# Altium schematic sheet Stage3G_current_limit.SchDoc
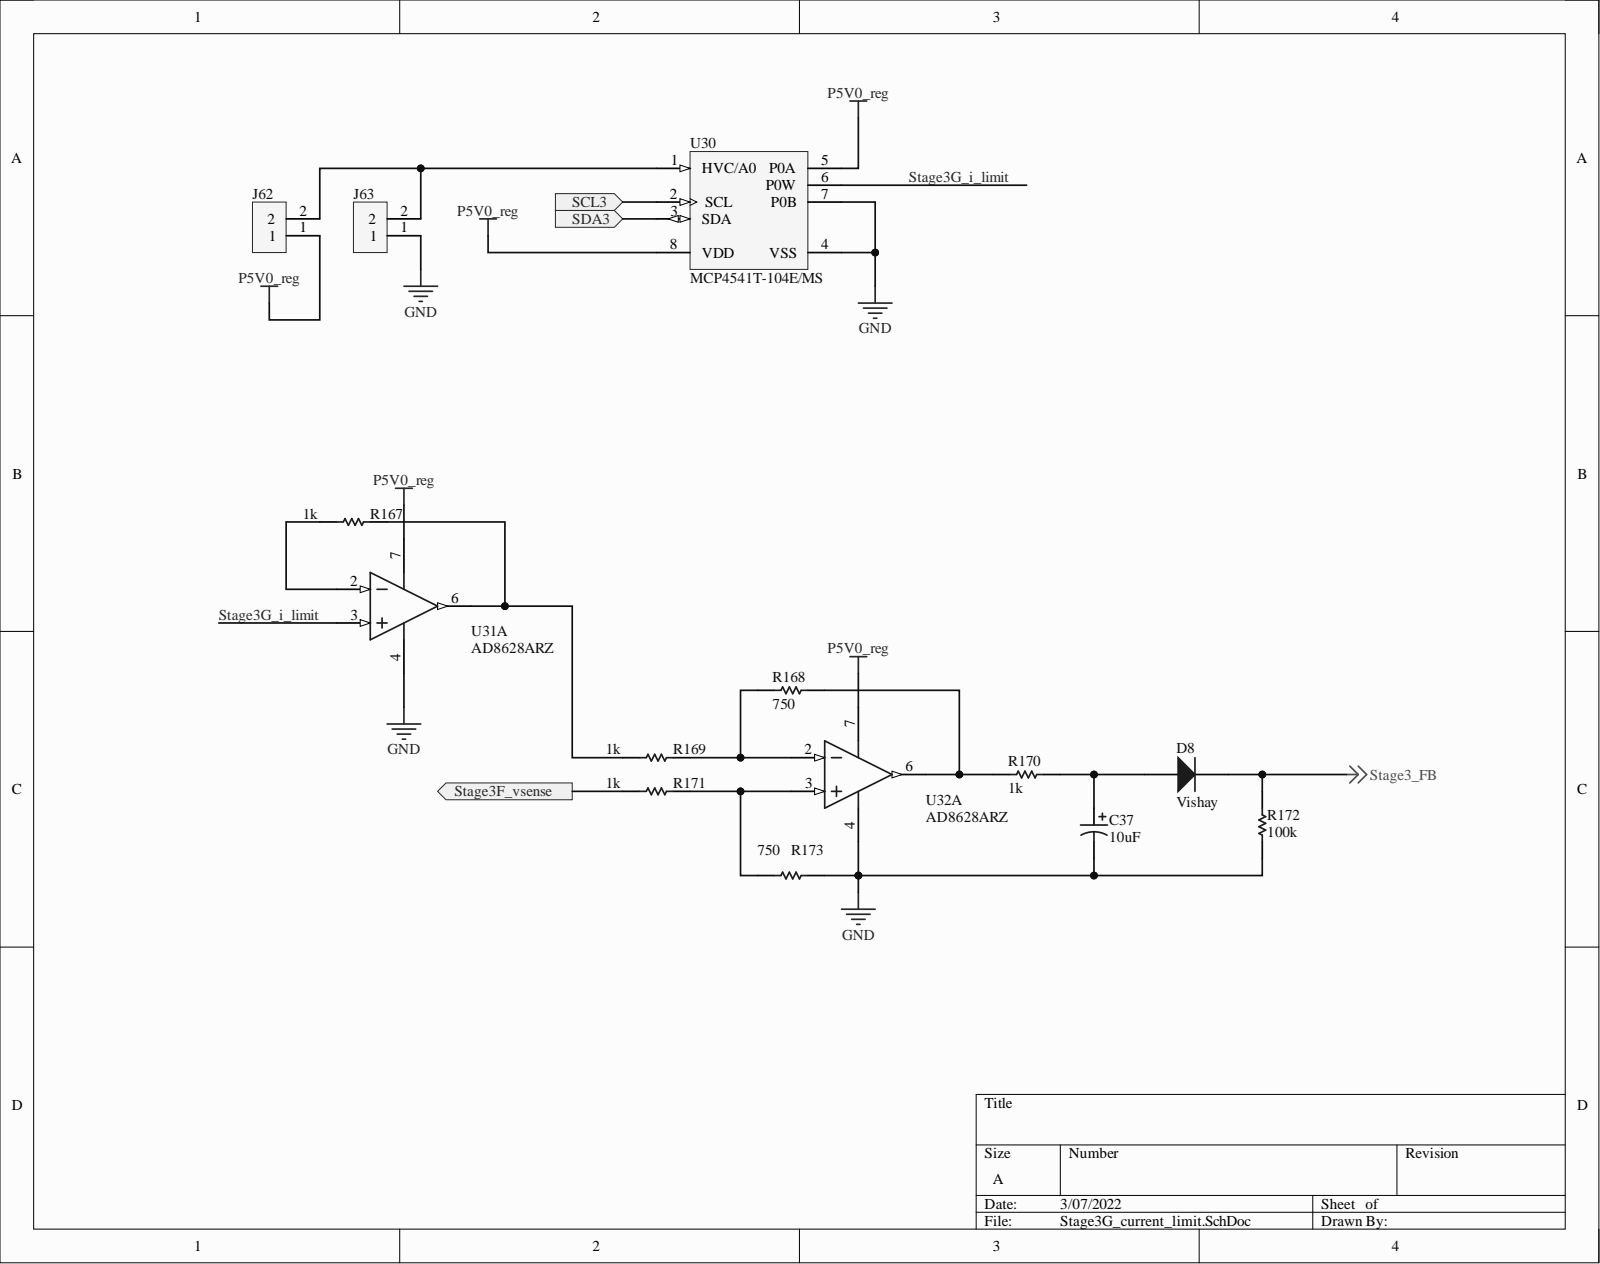
[diagram p0: Stage3G_current_limit.SchDoc]

## Parts
C37  10uF  [PCB-45uljczbzgwzo9m7ql45-1]
D8  Vishay  [PCB-aq0vep24p5p345c98j9h-1]
J62  (no value)  [PCB-omgsbkhp90n7lpri88og-1]
J63  (no value)  [PCB-omgsbkhp90n7lpri88og-1]
R167  1k  [PCB-0002-00367-1]
R168  750  [RESC2013X60X35NL10T20]
R169  1k  [PCB-0002-00367-1]
R170  1k  [PCB-0002-00367-1]
R171  1k  [PCB-0002-00367-1]
R172  100k  [RESC2013X60X35LL10T20]
R173  750  [RESC2013X60X35NL10T20]
U30  MCP4541T-104E/MS  [MSOP-MS8_L]
U31  AD8628ARZ  [ADI-R-8_L]  (part A)
U32  AD8628ARZ  [ADI-R-8_L]  (part A)

## Ports
SCL3, SDA3, Stage3F_vsense

## Nets
GND: C37.2 J63.1 R172.1 R173.2 U30.4(VSS) U30.7(P0B) U31.4 U32.4
P5V0_reg: J62.1 U30.5(P0A) U30.8(VDD) U31.7 U32.7
Stage3_FB: D8.1 R172.2
SCL3: U30.2(SCL) ; port SCL3
SDA3: U30.3(SDA) ; port SDA3
Stage3F_vsense: R171.1 ; port Stage3F_vsense
Stage3G_i_limit: U30.6(P0W) U31.3
NetC37_1: C37.1 D8.2 R170.2
NetJ62_2: J62.2 J63.2 U30.1(HVC/A0)
NetR167_1: R167.1 U31.2
NetR167_2: R167.2 R169.1 U31.6
NetR168_1: R168.1 R169.2 U32.2
NetR168_2: R168.2 R170.1 U32.6
NetR171_2: R171.2 R173.1 U32.3

## Library data of the parts
C37 = CMP-7610bd40367da9d7-6: Capacitor; Aluminum Electrolytic; Fc Radial Elec Cap 10uF 50V; Manufacturer Part Number: EEU-FC1H100L; Capacitance: 10.0 µF; Capacitance Tolerance: ±20%; Lead-Free Status: Lead Free; Voltage Rating (DC): 50.0 V; Component Kind: Standard; Dielectric Material: Aluminum Electrolytic; Library Name: My Personal Space; Lifecycle Status: Not Listed by Manufacturer; Mounting Style: Through Hole; Number of Pins: 2; Octopart URL: https://octopart.com/eeu-fc1h100l-panasonic-39478539; OctopartID: 7610bd40367da9d7; Operating Temperature: 105 °C (max); Packaging: Bulk; REACH SVHC Compliance: No SVHC; RoHS: Compliant; Size-Diameter: 5.00 mm; Size-Height: 11.0 mm; Size-Length: 11.0 mm
D8 = CMP-93ae58ff32594c69-2: S1G Series 1 A 400 V Surface Mount Glass Passivated Rectifier - DO-214AC; Manufacturer Part Number: S1G-E3/61T; Capacitance: 12.0 pF; Current Rating: 1.00 A; Lead-Free Status: Lead Free; Output Current: 1.00 A (max); Polarity: Standard; Voltage Rating (DC): 400 V; Component Kind: Standard; Library Name: My Personal Space; Mounting Style: Surface Mount; Number of Pins: 2; Octopart URL: http://octopart.com/s1g-e3%2F61t-vishay-39552145; OctopartID: 93ae58ff32594c69; Packaging: Cut Tape (CT), Tape & Reel (TR); RoHS: Compliant
J62 J63 = CMP-748fbcb73d6bab48-30: Pitch 2.54 mm, Single Row, 2pins; Component Kind: Standard; Library Name: My Personal Space
R167 R169-R171 = CMP-b013793a15d56fd4-2: Res Thick Film 0805 1K Ohm 1% 1/8W ±100ppm/°C Molded SMD SMD Paper Tape on Plastic Reel; Manufacturer Part Number: CR0805-FX-1001ELF; Case/Package (SI): 2012; Power Rating: 125 mW; Resistance: 1.00 kΩ; Temperature Coefficient: -100 ppm/°C to 100 ppm/°C; Voltage Rating (DC): 150 V; Case/Package: 0805; Component Kind: Standard; Library Name: My Personal Space; Mounting Style: Surface Mount; Number of Pins: 2; Octopart URL: https://octopart.com/cr0805-fx-1001elf-bourns-2326688; OctopartID: b013793a15d56fd4; Operating Temperature: -55.0 °C to 155 °C; Packaging: Tape & Reel (TR), Cut Tape (CT); REACH SVHC Compliance: No SVHC; Resistance Tolerance: ±1%; RoHS: Compliant; Simulation: SIM-vjisq6q3u7vp6b0mgwb9-1; Size-Length: 2.01 mm; Size-Thickness: 508 µm; Size-Width: 1.24 mm
R168 R173 = CMP-5384667-2: Thick Film Resistors - SMD 750 OHM 5%; Manufacturer Part Number: RC0805JR-13750RL; Lead-Free Status: Lead Free; Resistance: 750.0 Ω; Case/Package: 0805; Component Kind: Standard; Library Name: My Personal Space; Mounting Style: Surface Mount; Octopart Url: https://octopart.com/rc0805jr-13750rl-yageo-24906633; OctopartID: 510499727feb8ec3; Resistance Tolerance: ±5%; RoHS: Compliant
R172 = CMP-1875647-2: RES SMD 38.3K OHM 1% 1/8W 0805; Manufacturer Part Number: RC0805FR-0738K3L; Case/Package (SI): 2012; Lead-Free Status: Lead Free; Power Rating: 0.125 W; Resistance: 38300.0 Ω; Voltage Rating (DC): 150.0 V; Case/Package: 0805; Component Kind: Standard; Library Name: My Personal Space; Mounting Style: Surface Mount; Octopart Url: https://octopart.com/rc0805fr-0738k3l-yageo-1177806; OctopartID: 2daae4b1f002f26d; Resistance Tolerance: ±1%; RoHS: Compliant; Size-Height: 0.0005 m; Size-Length: 0.002 m; Size-Width: 0.00125 m
U30 = CMP-1074022-3: 7-Bit Single I2C Digital POT with Non-Volatile Memory, 8-pin MSOP, Extended Temperature, Tape and Reel; Resistance Tolerance: ±20%; Component Kind: Standard; Library Name: My Personal Space; Lifecycle Status: Active; Manufacturer Part Number: MCP4541T-104E/MS; Octopart Url: https://octopart.com/mcp4541t-104e%2Fms-microchip-16096136; OctopartID: a1f1680e1f1f7e36; RoHS: Compliant
U31 U32 = CMP-2260891-3: Single Channel RRIO Operational Amplifier, 2.5 MHz BW, 1 V/us SR, Industrial, 8-pin SOIC (R-8), Tube; Bandwidth: 2500000.0 Hz; Common Mode Rejection Ratio: 140.0 dB; Input Capacitance: 1e-11 F; Input Impedance: 5e+11 Ω; Lead-Free Status: Contains Lead; Mil-Spec: No; Number of Channels: 1; Slew Rate: 1.0 V/μs; Supply Current: 0.0011 A; Supply Voltage (DC): 5.0 V; Case/Package: SOIC; Component Kind: Standard; Gain Bandwidth Product: 2500000.0 Hz; Library Name: My Personal Space; Lifecycle Status: Active; Manufacturer Part Number: AD8628ARZ; Mounting Style: Surface Mount; Number of Circuits: 1; Number of Pins: 8; Octopart Url: https://octopart.com/ad8628arz-analog+devices-412641; OctopartID: cd22042ea3a512e5; Packaging: Tube; REACH SVHC Compliance: No SVHC; RoHS: Compliant
